# Altium schematic sheet ESP32-S3-WROOM-1-BREAKOUT.SchDoc
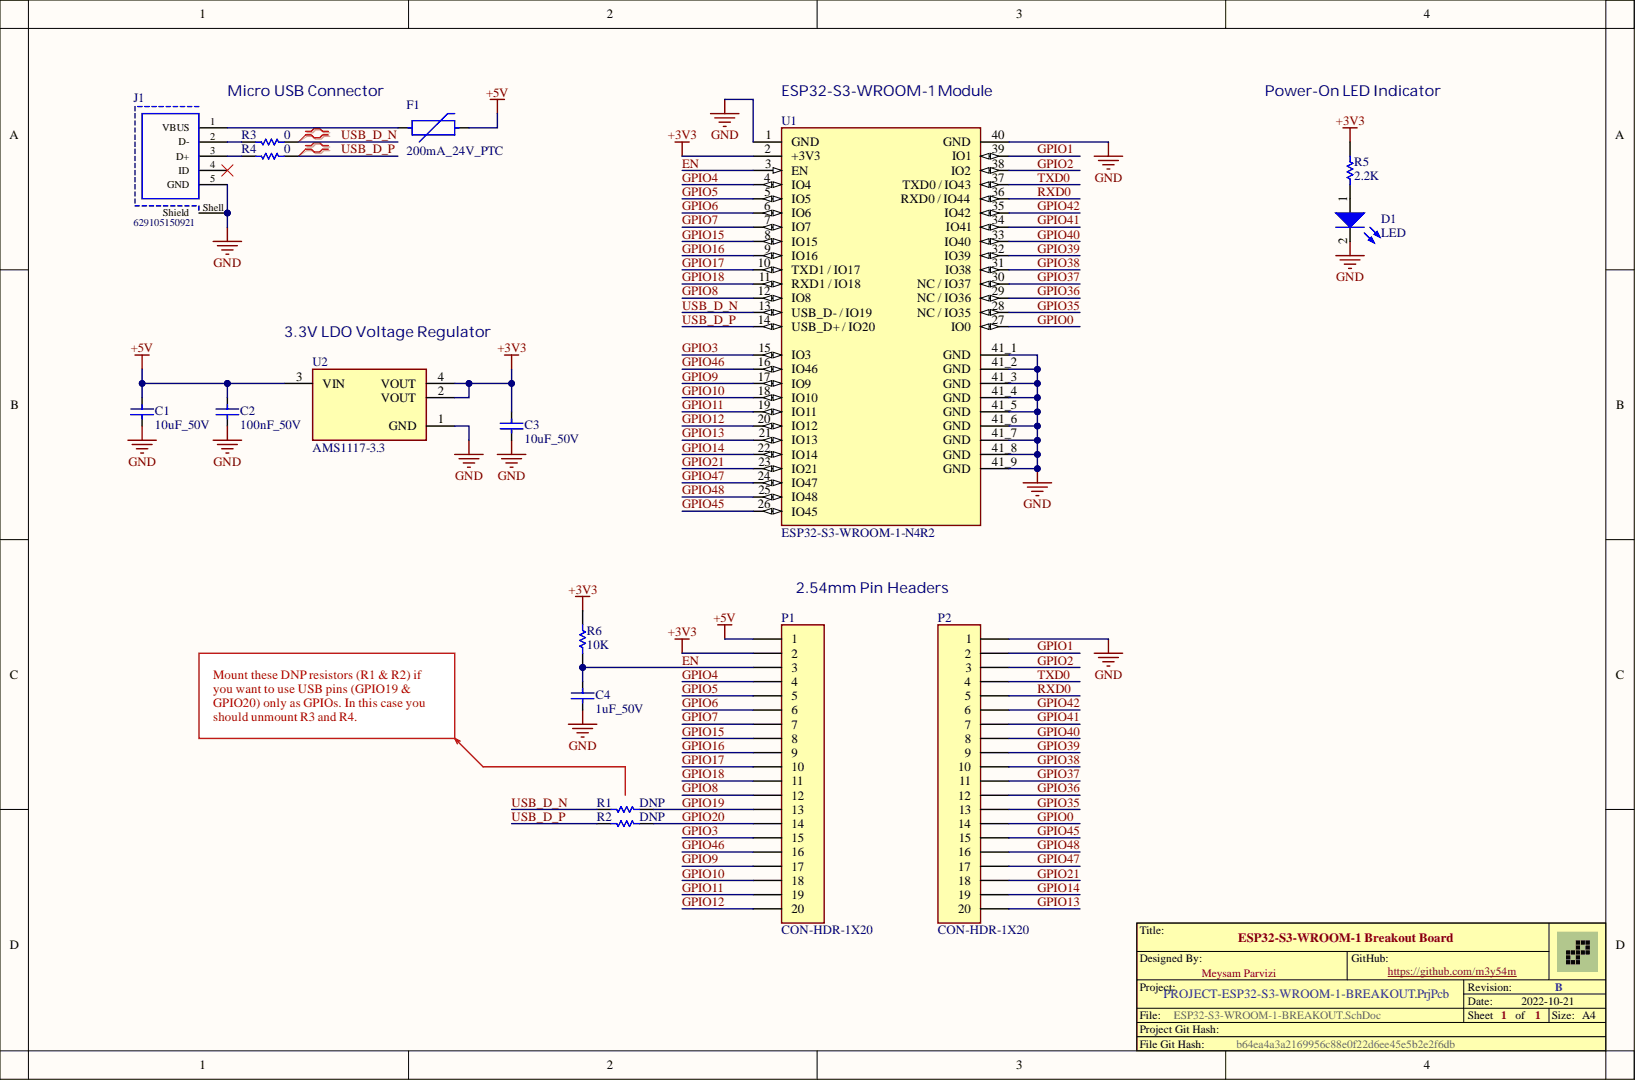
[diagram p0: ESP32-S3-WROOM-1-BREAKOUT.SchDoc]

## Parts
C1  value 10uF_50V  [C0805]  (hidden comment: C0805C104K5RAC7411)
C2  value 100nF_50V  [C0805]  (hidden comment: C0805C104K5RAC7411)
C3  value 10uF_50V  [C0805]  (hidden comment: C0805C104K5RAC7411)
C4  value 1uF_50V  [C0805]  (hidden comment: C0805C104K5RAC7411)
D1  LED  [LED0805]
F1  value 200mA_24V_PTC  [R1206]  (hidden comment: NANOSMDC020F-2)
J1  629105150921  [CON-MICRO-USB-B-SMD-WITH-HOLDER]
P1  CON-HDR-1X20  [CON-HDR-1X20-2.54]
P2  CON-HDR-1X20  [CON-HDR-1X20-2.54]
R1  value DNP  [R0603]  (hidden comment: CRG0805F10K)
R2  value DNP  [R0603]  (hidden comment: CRG0805F10K)
R3  value 0  [R0603]  (hidden comment: CRG0805F10K)
R4  value 0  [R0603]  (hidden comment: CRG0805F10K)
R5  value 2.2K  [R0805]  (hidden comment: CRG0805F10K)
R6  value 10K  [R0805]  (hidden comment: CRG0805F10K)
U1  ESP32-S3-WROOM-1-N4R2  [ESP32-S3-WROOM-1_NO-KEEPOUT]
U2  AMS1117-3.3  [SOT-223]

## Nets
+3V3: C3.1 P1.2 R5.1 R6.2 U1.2(+3V3) U2.2(VOUT) U2.4(VOUT)
+5V: C1.1 C2.1 F1.2 P1.1 U2.3(VIN)
GND: C1.2 C2.2 C3.2 C4.2 D1.2 J1.5(GND) J1.Shell(Shield) P2.1 U1.1(GND) U1.40(GND) U1.41_1(GND) U1.41_2(GND) U1.41_3(GND) U1.41_4(GND) U1.41_5(GND) U1.41_6(GND) U1.41_7(GND) U1.41_8(GND) U1.41_9(GND) U2.1(GND)
EN: C4.1 P1.3 R6.1 U1.3(EN)
GPIO0: P2.14 U1.27(IO0)
GPIO1: P2.2 U1.39(IO1)
GPIO2: P2.3 U1.38(IO2)
GPIO3: P1.15 U1.15(IO3)
GPIO4: P1.4 U1.4(IO4)
GPIO5: P1.5 U1.5(IO5)
GPIO6: P1.6 U1.6(IO6)
GPIO7: P1.7 U1.7(IO7)
GPIO8: P1.12 U1.12(IO8)
GPIO9: P1.17 U1.17(IO9)
GPIO10: P1.18 U1.18(IO10)
GPIO11: P1.19 U1.19(IO11)
GPIO12: P1.20 U1.20(IO12)
GPIO13: P2.20 U1.21(IO13)
GPIO14: P2.19 U1.22(IO14)
GPIO15: P1.8 U1.8(IO15)
GPIO16: P1.9 U1.9(IO16)
GPIO17: P1.10 U1.10(TXD1_/_IO17)
GPIO18: P1.11 U1.11(RXD1_/_IO18)
GPIO19: P1.13 R1.1
GPIO20: P1.14 R2.1
GPIO21: P2.18 U1.23(IO21)
GPIO35: P2.13 U1.28(NC_/_IO35)
GPIO36: P2.12 U1.29(NC_/_IO36)
GPIO37: P2.11 U1.30(NC_/_IO37)
GPIO38: P2.10 U1.31(IO38)
GPIO39: P2.9 U1.32(IO39)
GPIO40: P2.8 U1.33(IO40)
GPIO41: P2.7 U1.34(IO41)
GPIO42: P2.6 U1.35(IO42)
GPIO45: P2.15 U1.26(IO45)
GPIO46: P1.16 U1.16(IO46)
GPIO47: P2.17 U1.24(IO47)
GPIO48: P2.16 U1.25(IO48)
RXD0: P2.5 U1.36(RXD0_/_IO44)
TXD0: P2.4 U1.37(TXD0_/_IO43)
USB_D_N: R1.2 R3.1 U1.13(USB_D-_/_IO19)
USB_D_P: R2.2 R4.1 U1.14(USB_D+_/_IO20)
NetD1_1: D1.1 R5.2
NetF1_1: F1.1 J1.1(VBUS)
NetJ1_2: J1.2(D-) R3.2
NetJ1_3: J1.3(D+) R4.2

Not connected: J1 4(ID)

## Library data of the parts
C1-C4 = CAPACITOR: C0805 0.10 μF X7R 30ppm/°C 10.00% 50 V; Manufacturer: KEMET; Capacitance: 100nF; Material: Ceramic; Tolerance: 0.1; Voltage Rating: 50 V; Automotive: No; Category: Cap; Component Kind: Standard; ComponentLink2Description: Datasheet; ComponentLink2URL: https://content.kemet.com/datasheets/KEM_C1003_C0G_SMD.pdf; Device Class L1: Passive Components; Device Class L2: Capacitors; Device Class L3: Ceramic Capacitors; Height: 0.88mm; Ipc Land Pattern Name: CAPC200125X78; Lead Free: Yes; Library Name: CustomLib-Package.IntLib; Manufacturer Part Number: C0805C104K5RAC7411; Octopart: https://octopart.com/c0805c104k5rac7411-kemet-18508045; Package: C0805C-DN; RoHS: TRUE; Temperature: -55°C to +125°C; Temperature Characteristic: X7R; Temperature Coefficient: 30ppm/°C; Temperature Range High: +125°C; Temperature Range Low: -55°C
D1 = LED: Typical LED; Component Kind: Standard; Library Name: CustomLib-Package.IntLib
F1 = FUSE-PTC: FUSE PPTC 200MA (1206) 24V RESETTABLE; Component Kind: Standard; Library Name: CustomLib-Package.IntLib
J1 = CON-MICRO-USB-AB-RCPT-SMD: Micro USB 2.0 Type AB Receptacle WR-COM, Horizontal, SMT; Manufacturer: Wurth Elektronik; Contact Resistance: 30mOhm; Rated Current: 1.8A; Working Voltage: 30VAC; Component Kind: Standard; Connector Type: MicroUSB2.0TypeAB; Datasheet URL: https://katalog.we-online.de/em/datasheet/629105150921.pdf; Gender: Receptacle; Library Name: CustomLib-Package.IntLib; Manufacturer URL: http://www.we-online.de; Mounting Technology: SMT; Operating Temperature: -40to85degC; Orientation: Horizontal; Package Reference: 629105150921; Packaging: TapeandReel; Part Number: 629105150921; Publisher: WurthElektronik
P1 P2 = CON-HDR-1X20: Pin Header, 20-Pin; Component Kind: Standard; LatestRevisionDate: 17-Jul-2002; LatestRevisionNote: Re-released for DXP Platform.; Library Name: CustomLib-Package.IntLib; Publisher: Altium Limited
R1-R6 = RESISTOR: RES SMD 10K OHM 1% 1/8W 0805; Number of Resistors: 1; Power Rating: 125mW; Temperature Coefficient: 100ppm/°C; Voltage Rating: 150V; Case/Package: 0805; Component Kind: Standard; Depth: 1.25mm; ELV: Compliant; Height: 0.55mm; Length: 2mm; Library Name: CustomLib-Package.IntLib; Max Operating Temperature: 125°C; Min Operating Temperature: -55°C; Mount: Surface Mount; Number of Terminations: 2; Packaging: Tape and Reel; Radiation Hardening: No; Resistor Type: Thick Film; RoHS Compliant: Yes; Series: CRG Series; Technology: THICK FILM; Termination: Solder; Tolerance: 1%
U1 = ESP32-S3-WROOM-1: Multiprotocol Modules SMD Module, ESP32-S3R8, 3.3V, 8 MB Octal PSRAM Die, 16 MB Quad SPI Flash, PCB Antenna; MPN: ESP32-S3-WROOM-1-N16R2; MF: Espressif Systems; Check_prices: https://www.snapeda.com/parts/ESP32-WROOM-32/Espressif+Systems/view-part/?ref=eda; Component Kind: Standard; Digi-Key_Part_Number: 1965-ESP32-S3-WROOM-1-N16R2TR-ND; Library Name: CustomLib-Package.IntLib; Package: Module Espressif Systems; Price: 3.98; SnapEDA_Link: https://www.snapeda.com/parts/ESP32-WROOM-32/Espressif+Systems/view-part/?ref=snap; Supplier: Digikey; Supplier Link: https://www.digikey.com/en/products/detail/espressif-systems/ESP32-S3-WROOM-1-N16R2/16162644
U2 = LD1117: IC REG LINEAR 3.3V 800MA SOT223; Accuracy: 1%; Dropout Voltage: 1.1V; Input Bias Current: 5mA; Max Input Voltage: 15V; Max Output Current: 1.3A; Max Power Dissipation: 12W; Min Input Voltage: 5.3V; Number of Outputs: 1; Output Current: 950mA; Output Type: Fixed; Polarity: Positive; Quiescent Current: 10mA; Case/Package: SOT-223; Component Kind: Standard; Contact Plating: Tin; Height: 1.8mm; Lead Free: Lead Free; Length: 6.5mm; Library Name: CustomLib-Package.IntLib; Max Operating Temperature: 125°C; Max Output Voltage: 3.3V; Max Supply Voltage: 15V; Min Operating Temperature: -40°C; Min Output Voltage: 3.3V; Min Supply Voltage: 3.3V; Mount: Surface Mount; Nominal Output Voltage: 3.3V; Number of Pins: 4; Number of Regulators: 1; Number of Terminals: 4; Output Voltage: 3.3V; Output Voltage Accuracy: 1%; Package Quantity: 1; Packaging: Tape and Reel; Power Dissipation: 12W; Radiation Hardening: No; REACH SVHC: No SVHC; Termination: SMD/SMT; Weight: 0.01lb; Width: 3.5mm
